# Altium schematic sheet leds.SchDoc
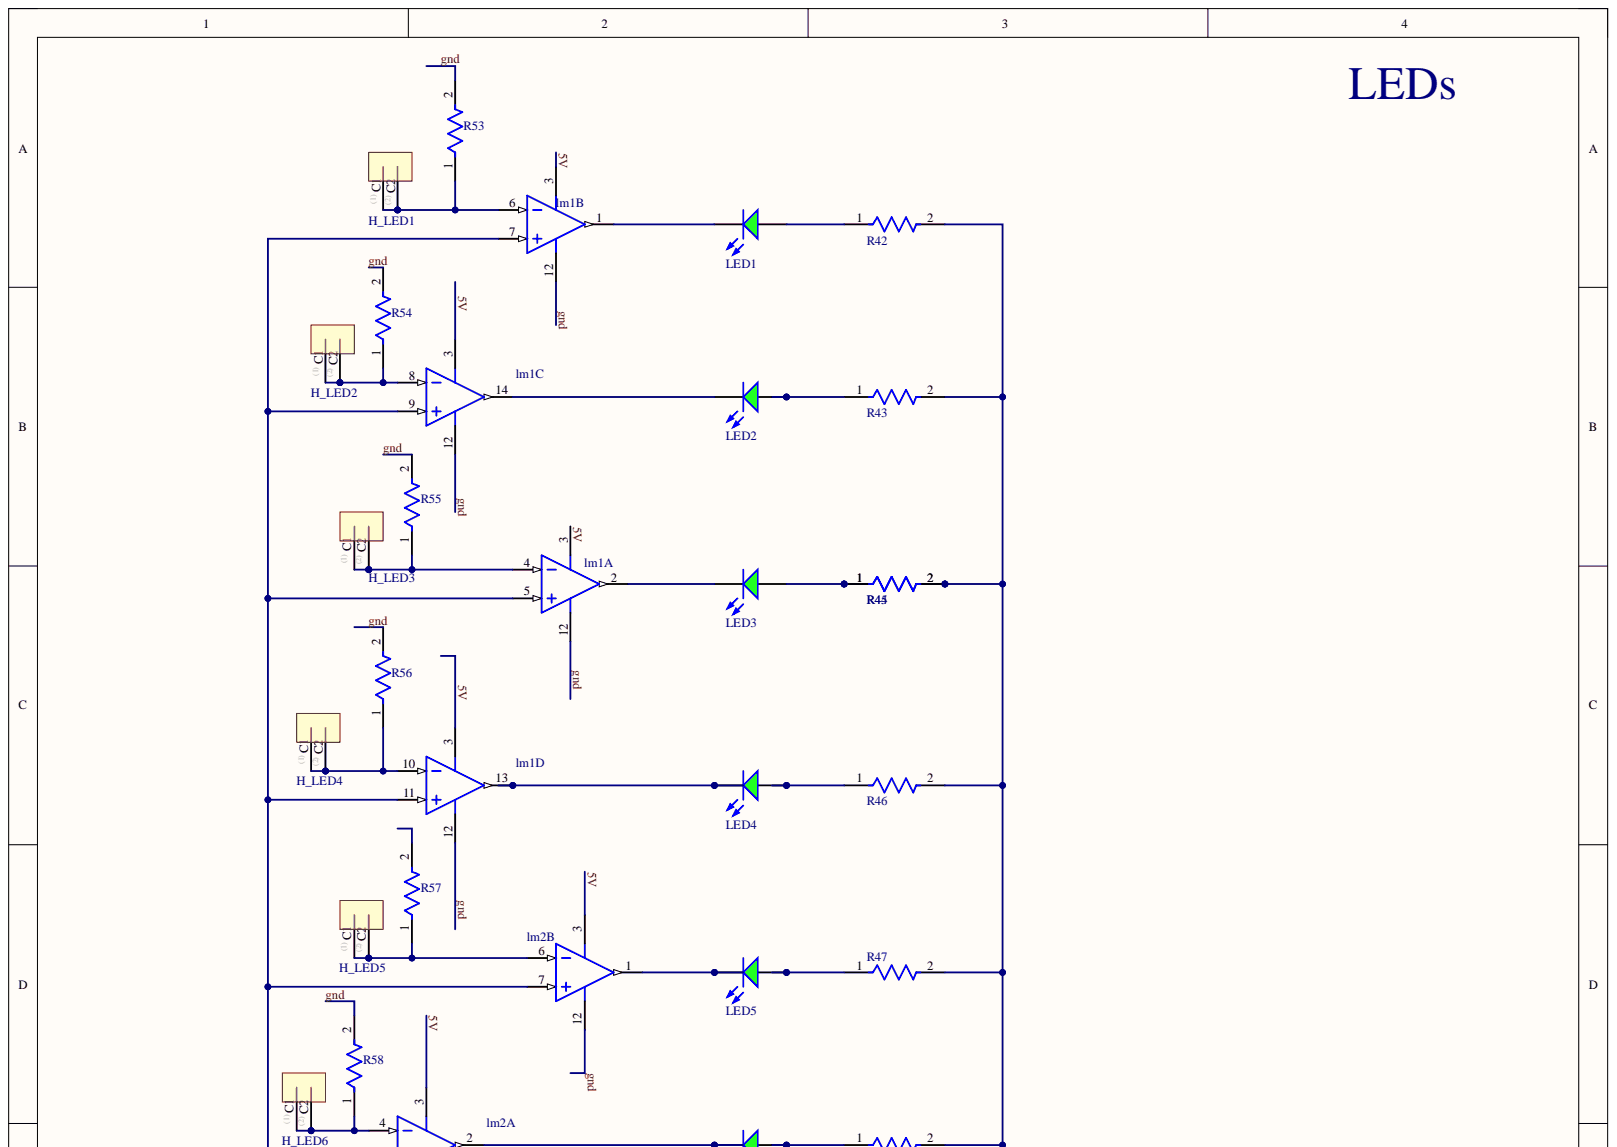
[diagram p0: leds.SchDoc, top]
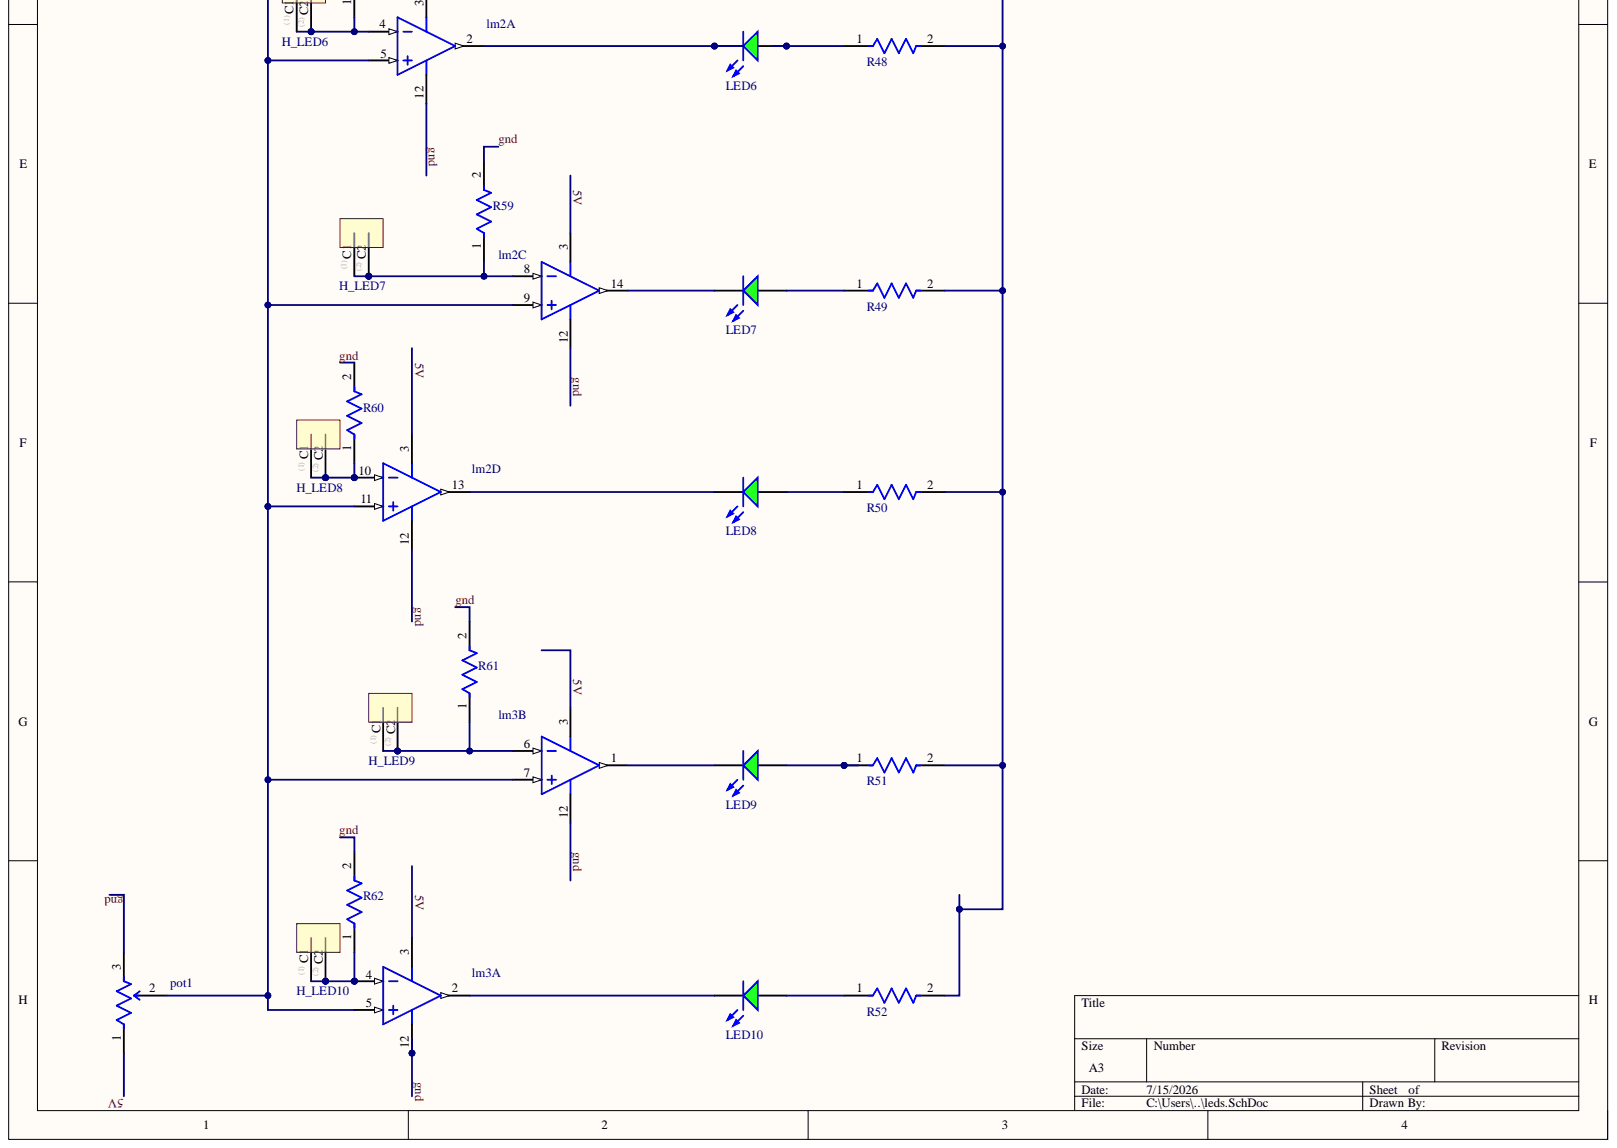
[diagram p1: leds.SchDoc, bottom]

## Parts
H_LED1  header 2x1  [header 2x1]  (value not drawn)
H_LED2  header 2x1  [header 2x1]  (value not drawn)
H_LED3  header 2x1  [header 2x1]  (value not drawn)
H_LED4  header 2x1  [header 2x1]  (value not drawn)
H_LED5  header 2x1  [header 2x1]  (value not drawn)
H_LED6  header 2x1  [header 2x1]  (value not drawn)
H_LED7  header 2x1  [header 2x1]  (value not drawn)
H_LED8  header 2x1  [header 2x1]  (value not drawn)
H_LED9  header 2x1  [header 2x1]  (value not drawn)
H_LED10  header 2x1  [header 2x1]  (value not drawn)
LED1  WP7113SGD  [KING-WP7113SGD_V]  (value not drawn)
LED2  WP7113SGD  [KING-WP7113SGD_V]  (value not drawn)
LED3  WP7113SGD  [KING-WP7113SGD_V]  (value not drawn)
LED4  WP7113SGD  [KING-WP7113SGD_V]  (value not drawn)
LED5  WP7113SGD  [KING-WP7113SGD_V]  (value not drawn)
LED6  WP7113SGD  [KING-WP7113SGD_V]  (value not drawn)
LED7  WP7113SGD  [KING-WP7113SGD_V]  (value not drawn)
LED8  WP7113SGD  [KING-WP7113SGD_V]  (value not drawn)
LED9  WP7113SGD  [KING-WP7113SGD_V]  (value not drawn)
LED10  WP7113SGD  [KING-WP7113SGD_V]  (value not drawn)
lm1  LM339J  [J0014A]  (value not drawn)  (parts A,B,C,D)
lm2  LM339J  [J0014A]  (value not drawn)  (parts A,B,C,D)
lm3  LM339J  [J0014A]  (value not drawn)  (parts A,B)
pot1  3296W-1-501LF  [FP-3296W-MFG]  (value not drawn)
R42  MRS16000C2002FCT00  [FP-MRS1600-MFG]  (value not drawn)
R43  MRS16000C2002FCT00  [FP-MRS1600-MFG]  (value not drawn)
R44  MRS16000C2002FCT00  [FP-MRS1600-MFG]  (value not drawn)
R45  MRS16000C2002FCT00  [FP-MRS1600-MFG]  (value not drawn)
R46  MRS16000C2002FCT00  [FP-MRS1600-MFG]  (value not drawn)
R47  MRS16000C2002FCT00  [FP-MRS1600-MFG]  (value not drawn)
R48  MRS16000C2002FCT00  [FP-MRS1600-MFG]  (value not drawn)
R49  MRS16000C2002FCT00  [FP-MRS1600-MFG]  (value not drawn)
R50  MRS16000C2002FCT00  [FP-MRS1600-MFG]  (value not drawn)
R51  MRS16000C2002FCT00  [FP-MRS1600-MFG]  (value not drawn)
R52  MRS16000C2002FCT00  [FP-MRS1600-MFG]  (value not drawn)
R53  MRS16000C2002FCT00  [FP-MRS1600-MFG]  (value not drawn)
R54  MRS16000C2002FCT00  [FP-MRS1600-MFG]  (value not drawn)
R55  MRS16000C2002FCT00  [FP-MRS1600-MFG]  (value not drawn)
R56  MRS16000C2002FCT00  [FP-MRS1600-MFG]  (value not drawn)
R57  MRS16000C2002FCT00  [FP-MRS1600-MFG]  (value not drawn)
R58  MRS16000C2002FCT00  [FP-MRS1600-MFG]  (value not drawn)
R59  MRS16000C2002FCT00  [FP-MRS1600-MFG]  (value not drawn)
R60  MRS16000C2002FCT00  [FP-MRS1600-MFG]  (value not drawn)
R61  MRS16000C2002FCT00  [FP-MRS1600-MFG]  (value not drawn)
R62  MRS16000C2002FCT00  [FP-MRS1600-MFG]  (value not drawn)

## Nets
5V: lm1.3 lm2.3 lm3.3 pot1.1
gnd: lm1.12 lm2.12 lm3.12 pot1.3 R53.2 R54.2 R55.2 R56.2 R58.2 R59.2 R60.2 R61.2 R62.2
NetH_LED1_1: H_LED1.1 H_LED1.2 lm1.6 R53.1
NetH_LED2_1: H_LED2.1 H_LED2.2 lm1.8 R54.1
NetH_LED3_1: H_LED3.1 H_LED3.2 lm1.4 R55.1
NetH_LED4_1: H_LED4.1 H_LED4.2 lm1.10 R56.1
NetH_LED5_1: H_LED5.1 H_LED5.2 lm2.6 R57.1
NetH_LED6_1: H_LED6.1 H_LED6.2 lm2.4 R58.1
NetH_LED7_1: H_LED7.1 H_LED7.2 lm2.8 R59.1
NetH_LED8_1: H_LED8.1 H_LED8.2 lm2.10 R60.1
NetH_LED9_1: H_LED9.1 H_LED9.2 lm3.6 R61.1
NetH_LED10_1: H_LED10.1 H_LED10.2 lm3.4 R62.1
NetLED1_1: LED1.1 R42.1
NetLED1_2: LED1.2 lm1.1
NetLED2_1: LED2.1 R43.1
NetLED2_2: LED2.2 lm1.14
NetLED3_1: LED3.1 R44.1 R45.1
NetLED3_2: LED3.2 lm1.2
NetLED4_1: LED4.1 R46.1
NetLED4_2: LED4.2 lm1.13
NetLED5_1: LED5.1 R47.1
NetLED5_2: LED5.2 lm2.1
NetLED6_1: LED6.1 R48.1
NetLED6_2: LED6.2 lm2.2
NetLED7_1: LED7.1 R49.1
NetLED7_2: LED7.2 lm2.14
NetLED8_1: LED8.1 R50.1
NetLED8_2: LED8.2 lm2.13
NetLED9_1: LED9.1 R51.1
NetLED9_2: LED9.2 lm3.1
NetLED10_1: LED10.1 R52.1
NetLED10_2: LED10.2 lm3.2
Netlm1_5: lm1.5 lm1.7 lm1.9 lm1.11 lm2.5 lm2.7 lm2.9 lm2.11 lm3.5 lm3.7 pot1.2
NetR42_2: R42.2 R43.2 R44.2 R45.2 R46.2 R47.2 R48.2 R49.2 R50.2 R51.2 R52.2

Not connected: R57 2

## Library data of the parts
H_LED1-H_LED10 = Heade 1x2: header male 2 pin; Parameter 1: H2x1; Component Kind: Standard; Library Name: Schlib1.SchLib
LED1-LED10 = CMP-2100-03698-1: LED Green, 62.5 mW, 25 mA, -40 to 85 degC, 2-Pin THD, RoHS, Bulk; Manufacturer: Kingbright; Dominant Wavelength: 568nm; Forward Voltage: 2.2V; Lens Style: Tinted; Luminous Intensity: 40mcd; Test Current: 20mA; Viewing Angle: 30degrees; Case/Package: Radial; Colour: Green; Component Kind: Standard; Datasheet URL: http://www.kingbrightusa.com/images/catalog/SPEC/WP7113SGD.pdf; Datasheet Version: Rev. 6B, 01/2015; Height: 8.6mm; Illumination Colour: Green; Lead Free: LeadFree; Library Name: Altium Content Vault; Package Description: 2-Pin Through Hole Device, Dia 5.9 mm, Body Length 8.6 mm; Package Quantity: 1000; Package Reference: WP7113SGD; Packaging: Bulk; RoHSCompliant: true; Wavelength: 568nm
lm1-lm3 = CMP-0060-00012-3: Low Power Low Offset Voltage Quad Comparator, 14-pin CerDIP; Manufacturer: Texas Instruments; PackageTopMarking: NSUZXYYTT*LM339J; PowerWise: N; Component Kind: Standard; ComponentLink1Description: Datasheet URL; ComponentLink1URL: http://www.ti.com/general/docs/lit/getliterature.tsp?genericPartNumber=LM339-N&fileType=pdf; CompType: Comparator; Library Name: Altium Content Vault; PackageDescription: Cerdip, .400 x .310in Body, 14 Lead, .300in Centers, .100in Pitch; PackageReference: J0014A; PinCount: 14; RoHS: N
pot1 = CMP-07234-000046-1: TRIMMER 500 OHM 0.5W PC PIN TOP; Max Power Dissipation: 500mW; Number of Turns: 25; Resistance: 10kR; Resistance Tolerance: 10%; Temperature Coefficient: 100ppm/°C; Color: Blue; Component Kind: Standard; Contact Plating: Tin; Depth: 4.83mm; Height: 0.395inch; Lead Free: Lead Free; Length: 9.53mm; Library Name: Altium Content Vault; Max Operating Temperature: 125°C; Military Standard: Not; Min Operating Temperature: -55°C; Mount: Through Hole; Package Quantity: 50; Packaging: Rail/Tube; Power Rating: 500mW; Radiation Hardening: No; REACH SVHC: No SVHC; Resistor Type: Cermet; RoHS Compliant: Yes; Series: 3296; Taper: Linear; Technology: CERMET; Termination: Pin; Tolerance: 10%; Weight: 0.03oz; Width: 9.53mm
R42-R62 = CMP-02408-000019-1: RES 20K OHM 0.4W 1% AXIAL; Max Power Dissipation: 400mW; Resistance: 20kR; Temperature Coefficient: 50ppm/°C; Case/Package: Conformal; Component Kind: Standard; Construction: Cylindrical; Contact Plating: Tin; Diameter: 1.6mm; Length: 3.6mm; Library Name: Altium Content Vault; Max Operating Temperature: 155°C; Military Standard: Not; Min Operating Temperature: -55°C; Mount: Through Hole; Number of Terminations: 2; Packaging: Tape and Reel; Power Rating: 400mW; Radiation Hardening: No; Resistor Type: Metal Film; RoHS Compliant: Yes; Series: MRS16; Technology: THIN FILM; Termination: Axial; Tolerance: 1%
